# Altium schematic sheet ThinkBoard-LoRa-Node-V1.SchDoc
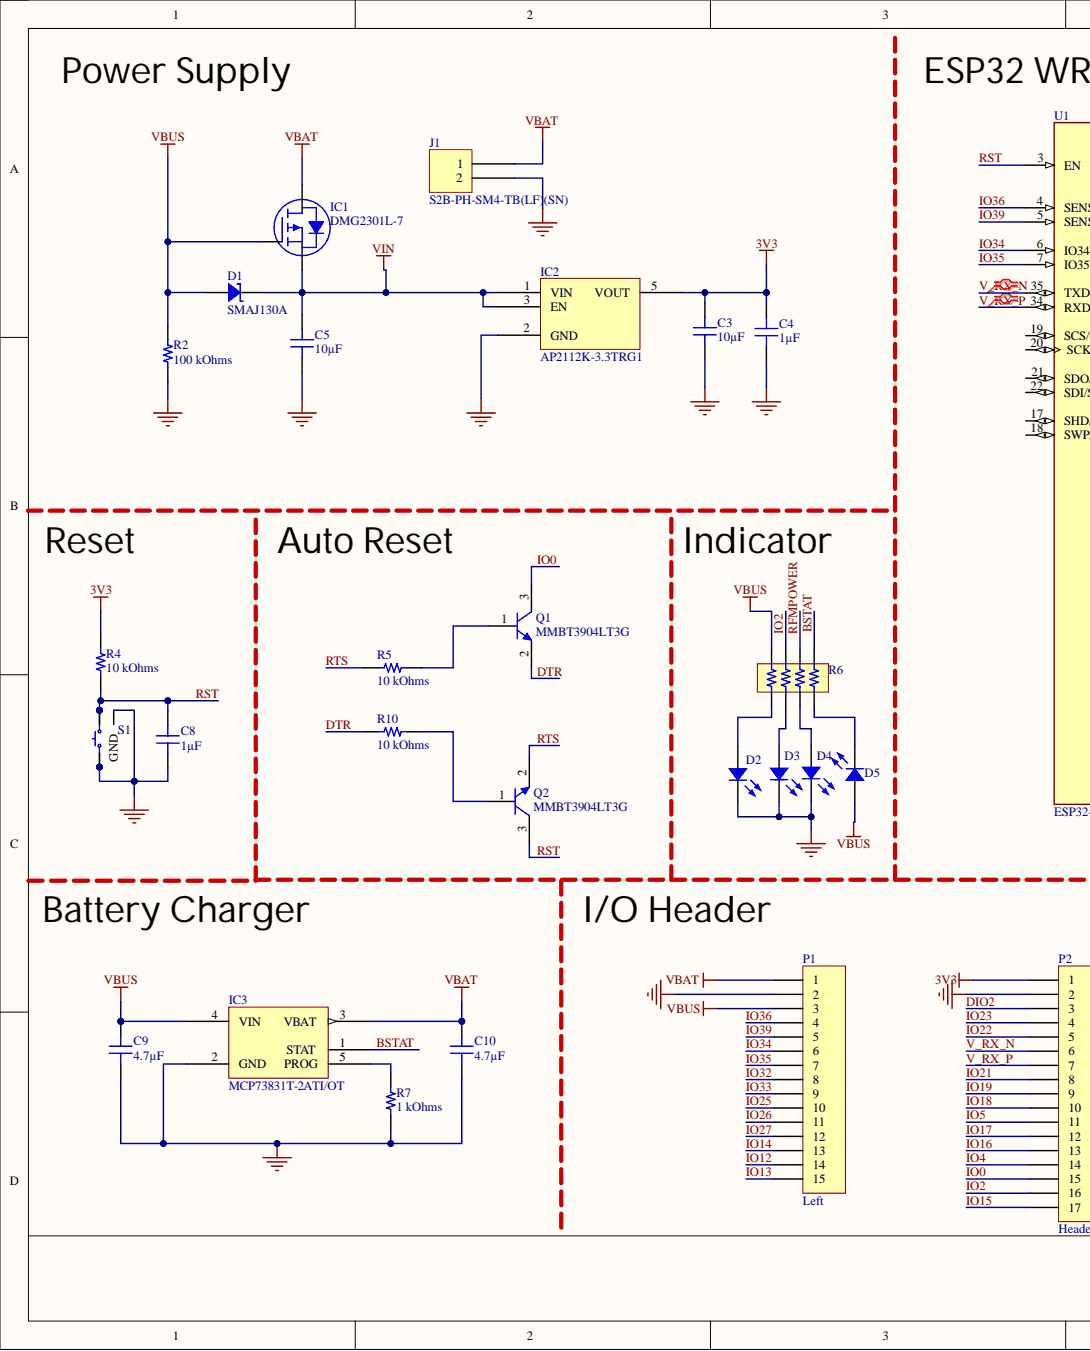
[diagram p0: ThinkBoard-LoRa-Node-V1.SchDoc, left]
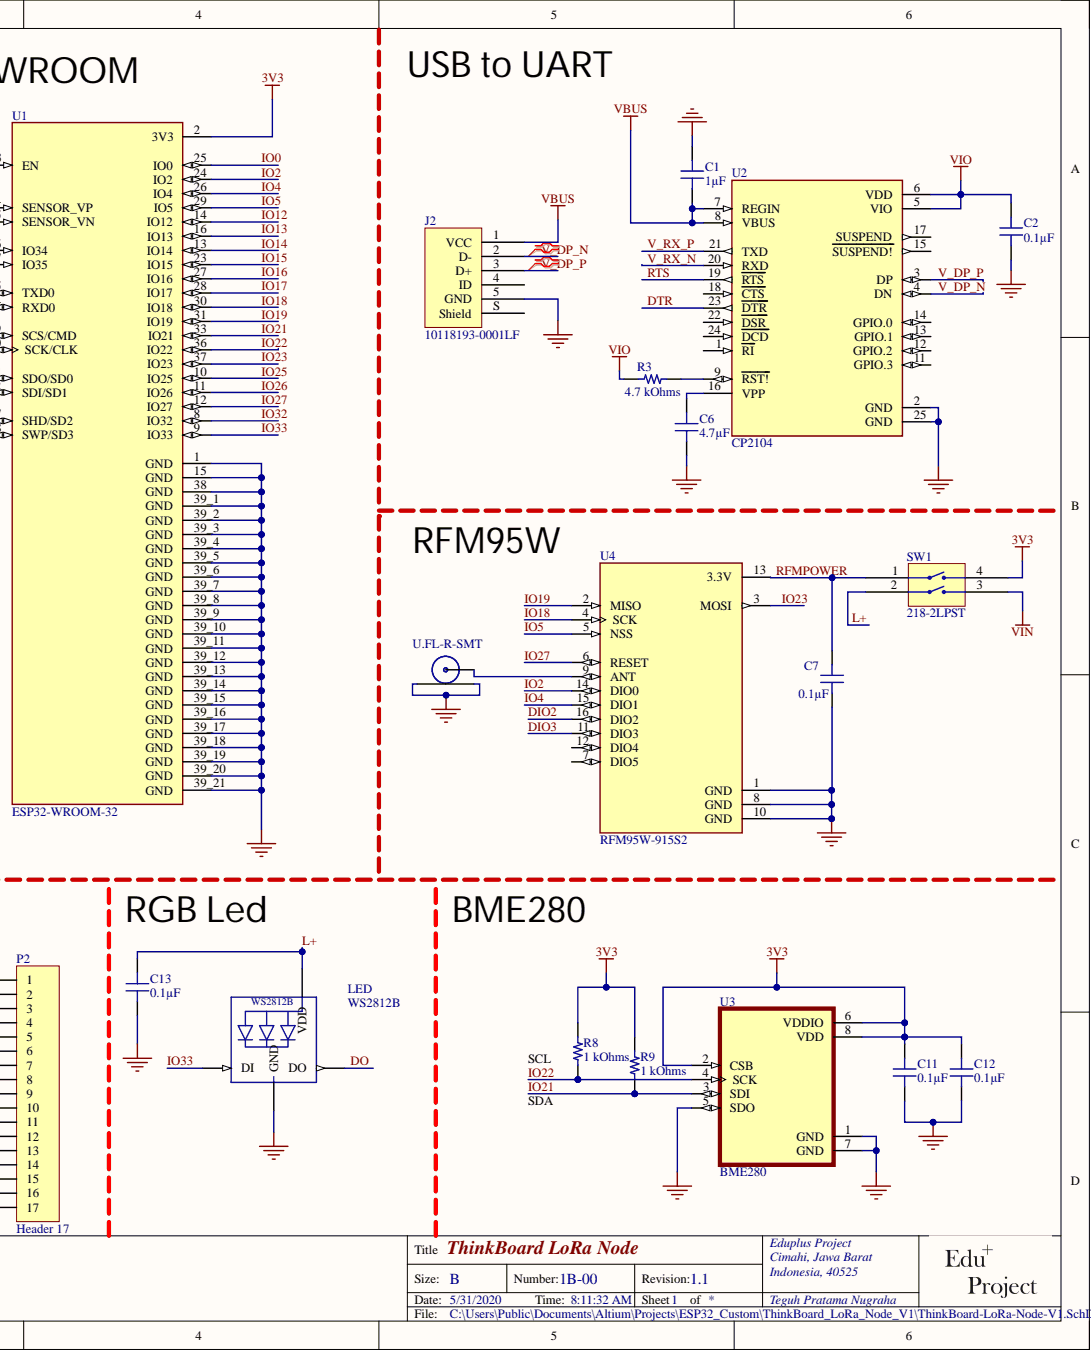
[diagram p1: ThinkBoard-LoRa-Node-V1.SchDoc, right]

## Parts
C1  1µF  [CAP 0603_1608]
C2  0.1µF  [CAP 0603_1608]
C3  10µF  [CAP 0603_1608]
C4  1µF  [CAP 0603_1608]
C5  10µF  [CAP 0603_1608]
C6  4.7µF  [CAP 0603_1608]
C7  0.1µF  [CAP 0603_1608]
C8  1µF  [CAP 0603_1608]
C9  4.7µF  [CAP 0805_2012]
C10  4.7µF  [CAP 0805_2012]
C11  0.1µF  [CAP 0603_1608]
C12  0.1µF  [CAP 0603_1608]
C13  0.1µF  [CAP 0603_1608]
D1  SMAJ130A  [DO214AC SMA]
D2  Yellow  [LED 0603_1608 YELLOW]  (value not drawn)
D3  Blue  [LED 0603_1608 BLUE]  (value not drawn)
D4  Green  [LED 0603_1608 GREEN]  (value not drawn)
D5  Red  [LED 0603_1608 RED]  (value not drawn)
IC1  DMG2301L-7  [DIODES SOT-23-3]
IC2  AP2112K-3.3TRG1  [DIODES SOT-23-5 W5]
IC3  MCP73831T-2ATI/OT  [MICROCHIP SOT-23-5 OT]
J1  S2B-PH-SM4-TB(LF)(SN)  [JST S2B-PH-SM4-TB]
J2  10118193-0001LF  [FCI USB 10118193-0001LF]
LED  WS2812B  [LED3535]
P1  Left  [HDR1X15]
P2  Header 17  [HDR1X17]
Q1  MMBT3904LT3G  [ON SEMI SOT-23-3]
Q2  MMBT3904LT3G  [ON SEMI SOT-23-3]
R2  100 kOhms  [RES 0603_1608]
R3  4.7 kOhms  [RES 0603_1608]
R4  10 kOhms  [RES 0603_1608]
R5  10 kOhms  [RES 0603_1608]
R6  EXB-28V112JX  [RESNET 0804-8 CONVEX]  (value not drawn)
R7  1 kOhms  [RES 0603_1608]
R8  1 kOhms  [RES 0603_1608]
R9  1 kOhms  [RES 0603_1608]
R10  10 kOhms  [RES 0603_1608]
S1  FSM1LP  [TE FSM1LPATR]  (value not drawn)
SW1  218-2LPST  [CTS 218-2LPJ]
U1  ESP32-WROOM-32  [MODULE_ESP32-WROOM-32]
U2  CP2104  [QFN50P400X400X80-25N]
U3  BME280  [PSON65P250X250X100-8N]
U4  RFM95W-915S2  [XCVR_RFM95W-915S2]
  U.FL-R-SMT  [U.FL-R-SMT]

## Nets
3V3: C3.2 C4.2 C11.2 C12.2 IC2.5(VOUT) P2.1 R4.2 R8.2 R9.2 SW1.4 U1.2(3V3) U3.2(CSB) U3.6(VDDIO) U3.8(VDD)
GND: C1.2 C2.1 C3.1 C4.1 C5.1 C6.1 C7.1 C8.1 C9.1 C10.1 C11.1 C12.1 C13.2 D2.2 D3.2 D4.2 IC2.2(GND) IC3.2(GND) J1.2 J2.5(GND) LED.3-GND(GND) P1.2 P2.2 R2.1 R7.1 S1.1 S1.2 S1.5(GND) U1.1(GND) U1.15(GND) U1.38(GND) U1.39_1(GND) U1.39_2(GND) U1.39_3(GND) U1.39_4(GND) U1.39_5(GND) U1.39_6(GND) U1.39_7(GND) U1.39_8(GND) U1.39_9(GND) U1.39_10(GND) U1.39_11(GND) U1.39_12(GND) U1.39_13(GND) U1.39_14(GND) U1.39_15(GND) U1.39_16(GND) U1.39_17(GND) U1.39_18(GND) U1.39_19(GND) U1.39_20(GND) U1.39_21(GND) U2.2(GND) U2.25(GND) U3.1(GND) U3.5(SDO) U3.7(GND) U4.1(GND) U4.8(GND) U4.10(GND) .P$2 .P$3
Net_P$1: U4.9(ANT) .P$1
VBAT: C10.2 IC1.3 IC3.3(VBAT) J1.1 P1.1
VBUS: C1.1 C9.2 D1.1 D5.1 IC1.1 IC3.4(VIN) J2.1(VCC) P1.3 R2.2 R6.4 U2.7(REGIN) U2.8(VBUS)
VIN: C5.2 D1.2 IC1.2 IC2.1(VIN) IC2.3(EN) SW1.3
VIO: C2.2 R3.1 U2.5(VIO) U2.6(VDD)
BSTAT: IC3.1(STAT) R6.1
DIO2: P2.3 U4.16(DIO2)
DIO3: U4.11(DIO3)
DO: LED.2-DOUT(DO)
DTR: Q1.2 R10.2 U2.23(DTR)
IO0: P2.15 Q1.3 U1.25(IO0)
IO2: P2.16 R6.3 U1.24(IO2) U4.14(DIO0)
IO4: P2.14 U1.26(IO4) U4.15(DIO1)
IO5: P2.11 U1.29(IO5) U4.5(NSS)
IO12: P1.14 U1.14(IO12)
IO13: P1.15 U1.16(IO13)
IO14: P1.13 U1.13(IO14)
IO15: P2.17 U1.23(IO15)
IO16: P2.13 U1.27(IO16)
IO17: P2.12 U1.28(IO17)
IO18: P2.10 U1.30(IO18) U4.4(SCK)
IO19: P2.9 U1.31(IO19) U4.2(MISO)
IO21: P2.8 R9.1 U1.33(IO21) U3.3(SDI)
IO22: P2.5 R8.1 U1.36(IO22) U3.4(SCK)
IO23: P2.4 U1.37(IO23) U4.3(MOSI)
IO25: P1.10 U1.10(IO25)
IO26: P1.11 U1.11(IO26)
IO27: P1.12 U1.12(IO27) U4.6(RESET)
IO32: P1.8 U1.8(IO32)
IO33: LED.4-DIN(DI) P1.9 U1.9(IO33)
IO34: P1.6 U1.6(IO34)
IO35: P1.7 U1.7(IO35)
IO36: P1.4 U1.4(SENSOR_VP)
IO39: P1.5 U1.5(SENSOR_VN)
L+: C13.1 LED.1-VDD(VDD) SW1.2
RFMPOWER: C7.2 R6.2 SW1.1 U4.13(3.3V)
RST: C8.2 Q2.3 R4.1 S1.3 S1.4 U1.3(EN)
RTS: Q2.2 R5.2 U2.19(RTS)
V_DP_N: J2.2(D-) U2.4(DN)
V_DP_P: J2.3(D+) U2.3(DP)
V_RX_N: P2.6 U1.35(TXD0) U2.20(RXD)
V_RX_P: P2.7 U1.34(RXD0) U2.21(TXD)
NetC6_2: C6.2 U2.16(VPP)
NetD2_1: D2.1 R6.8
NetD3_1: D3.1 R6.7
NetD4_1: D4.1 R6.6
NetD5_2: D5.2 R6.5
NetIC3_5: IC3.5(PROG) R7.2
NetQ1_1: Q1.1 R5.1
NetQ2_1: Q2.1 R10.1
NetR3_2: R3.2 U2.9(RST!)

Not connected: J2 4(ID) S(Shield); U1 17(SHD/SD2) 18(SWP/SD3) 19(SCS/CMD) 20(SCK/CLK) 21(SDO/SD0) 22(SDI/SD1); U2 1(RI) 11(GPIO.3) 12(GPIO.2) 13(GPIO.1) 14(GPIO.0) 15(SUSPEND!) 17(SUSPEND) 18(CTS) 22(DSR) 24(DCD); U4 7(DIO5) 12(DIO4)

## Library data of the parts
C1 C4 C8 = C0603C105K4RACAUTO: CAP CER 0603 1UF 16V X7R 10%; Manufacturer: KEMET; Database Table Name: Capacitors - Ceramic - 0603; Applications: Automotive, Bypass, Decoupling; Capacitance: 1µF; Component Kind: Standard; ComponentLink1Description: Datasheet; ComponentLink1URL: https://api.kemet.com/component-edge/download/datasheet/C0603C105K4RACAUTO.pdf; ComponentLink2Description: DigiKey Link; ComponentLink2URL: http://digikey.com/product-detail/en/kemet/C0603C105K4RACAUTO/399-17704-1-ND/8635855; Device Package: 0603 (1608 Metric); LastUpdated: 1/21/2020 12:02:09 AM; Library Name: Celestial Altium Library - altium_library (3).DbLib; Lifecycle Status: Active; Minimum Order: 1; Mounting Type: Surface Mount, MLCC; Operating Temperature: -55°C ~ 125°C; Package / Case: 0603 (1608 Metric); Packaging: TapeAndReel; Part Number: C0603C105K4RACAUTO; Part Status: Active; PartId: 2179; Price: 0.02; Ratings: AEC-Q200; Series: SMD Auto X7R; Size / Dimension: 0.063" L x 0.031" W (1.60mm x 0.80mm); Supplier 1: DigiKey; Supplier Part Number 1: 399-17704-1-ND; Temperature Coefficient: X7R; Thickness (Max): 0.037" (0.95mm); Tolerance: ±10%; Value: 1µF; Voltage - Rated: 16V
C2 C7 C11-C13 = C0603C105K4RACAUTO: CAP CER 0603 1UF 16V X7R 10%; Manufacturer: KEMET; Capacitance: 1µF; Database Table Name: Capacitors - Ceramic - 0603; Applications: Automotive, Bypass, Decoupling; Component Kind: Standard; ComponentLink1Description: Datasheet; ComponentLink1URL: https://api.kemet.com/component-edge/download/datasheet/C0603C105K4RACAUTO.pdf; ComponentLink2Description: DigiKey Link; ComponentLink2URL: http://digikey.com/product-detail/en/kemet/C0603C105K4RACAUTO/399-17704-1-ND/8635855; Device Package: 0603 (1608 Metric); LastUpdated: 1/21/2020 12:02:09 AM; Library Name: Celestial Altium Library - altium_library (3).DbLib; Lifecycle Status: Active; Minimum Order: 1; Mounting Type: Surface Mount, MLCC; Operating Temperature: -55°C ~ 125°C; Package / Case: 0603 (1608 Metric); Packaging: TapeAndReel; Part Number: C0603C105K4RACAUTO; Part Status: Active; PartId: 2179; Price: 0.02; Ratings: AEC-Q200; Series: SMD Auto X7R; Size / Dimension: 0.063" L x 0.031" W (1.60mm x 0.80mm); Supplier 1: DigiKey; Supplier Part Number 1: 399-17704-1-ND; Temperature Coefficient: X7R; Thickness (Max): 0.037" (0.95mm); Tolerance: ±10%; Value: 0.1µF; Voltage - Rated: 16V
C3 C5 = GRM188R60J106ME47D: CAP CER 10UF 6.3V X5R 0603; Manufacturer: Murata Electronics; Database Table Name: Capacitors - Ceramic - 0603; Tolerance: ±20%; Applications: General Purpose; Capacitance: 10µF; Component Kind: Standard; ComponentLink1Description: Datasheet; ComponentLink1URL: https://www.murata.com/~/media/webrenewal/support/library/catalog/products/capacitor/mlcc/c02e.ashx?la=en-us; ComponentLink2Description: DigiKey Link; ComponentLink2URL: http://digikey.com/product-detail/en/murata-electronics/GRM188R60J106ME47D/490-3896-1-ND/965938; Device Package: 0603 (1608 Metric); LastUpdated: 1/21/2020 12:02:09 AM; Library Name: Celestial Altium Library - altium_library (3).DbLib; Lifecycle Status: Active; Minimum Order: 1; Mounting Type: Surface Mount, MLCC; Operating Temperature: -55°C ~ 85°C; Package / Case: 0603 (1608 Metric); Packaging: TapeAndReel; Part Number: GRM188R60J106ME47D; Part Status: Active; PartId: 2351; Price: 0.08; Series: GRM; Size / Dimension: 0.063" L x 0.031" W (1.60mm x 0.80mm); Supplier 1: DigiKey; Supplier Part Number 1: 490-3896-1-ND; Temperature Coefficient: X5R; Thickness (Max): 0.035" (0.90mm); Value: 10µF; Voltage - Rated: 6.3V
C6 = CL10A475KA8NQNC: CAP CER 4.7UF 25V X5R 0603; Manufacturer: Samsung Electro-Mechanics; Database Table Name: Capacitors - Ceramic - 0603; Tolerance: ±10%; Applications: General Purpose; Capacitance: 4.7µF; Component Kind: Standard; ComponentLink1Description: Datasheet; ComponentLink1URL: http://www.samsungsem.com/kr/support/product-search/mlcc/CL10A475KA8NQNC.jsp; ComponentLink2Description: DigiKey Link; ComponentLink2URL: http://digikey.com/product-detail/en/samsung-electro-mechanics/CL10A475KA8NQNC/1276-1900-1-ND/3889986; Device Package: 0603 (1608 Metric); LastUpdated: 1/21/2020 12:02:09 AM; Library Name: Celestial Altium Library - altium_library (3).DbLib; Lifecycle Status: Active; Minimum Order: 1; Mounting Type: Surface Mount, MLCC; Operating Temperature: -55°C ~ 85°C; Package / Case: 0603 (1608 Metric); Packaging: TapeAndReel; Part Number: CL10A475KA8NQNC; Part Status: Active; PartId: 2349; Price: 0.07; Series: CL; Size / Dimension: 0.063" L x 0.031" W (1.60mm x 0.80mm); Supplier 1: DigiKey; Supplier Part Number 1: 1276-1900-1-ND; Temperature Coefficient: X5R; Thickness (Max): 0.035" (0.90mm); Value: 4.7µF; Voltage - Rated: 25V
C9 C10 = C0805X475K4RAC7800: CAP CER 4.7UF 16V X7R 0805; Manufacturer: KEMET; Database Table Name: Capacitors - Ceramic - 0805; Tolerance: ±10%; Applications: Boardflex Sensitive; Capacitance: 4.7µF; Component Kind: Standard; ComponentLink1Description: Datasheet; ComponentLink1URL: https://api.kemet.com/component-edge/download/datasheet/C0805X475K4RAC7800.pdf; ComponentLink2Description: DigiKey Link; ComponentLink2URL: http://digikey.com/product-detail/en/kemet/C0805X475K4RAC7800/399-15712-1-ND/7427592; Device Package: 0805 (2012 Metric); Features: Soft Termination; LastUpdated: 1/21/2020 12:03:03 AM; Library Name: Celestial Altium Library - altium_library (3).DbLib; Lifecycle Status: Active; Minimum Order: 1; Mounting Type: Surface Mount, MLCC; Operating Temperature: -55°C ~ 125°C; Package / Case: 0805 (2012 Metric); Packaging: TapeAndReel; Part Number: C0805X475K4RAC7800; Part Status: Active; PartId: 4698; Price: 0.21; Series: SMD Comm X7R Flex; Size / Dimension: 0.079" L x 0.049" W (2.00mm x 1.25mm); Supplier 1: DigiKey; Supplier Part Number 1: 399-15712-1-ND; Temperature Coefficient: X7R; Thickness (Max): 0.057" (1.45mm); Value: 4.7µF; Voltage - Rated: 16V
D1 = SMAJ130A: TVS DIODE 130V 209V SMA; Manufacturer: Bourns Inc.; Current - Peak Pulse (10/1000µs): 1.9A; Database Table Name: Diodes - TVS; Power - Peak Pulse: 400W; Power Line Protection: No; Type: Zener; Unidirectional Channels: 1; Voltage - Breakdown (Min): 144V; Applications: General Purpose; Component Kind: Standard; ComponentLink1Description: Datasheet; ComponentLink1URL: https://www.bourns.com/docs/Product-Datasheets/SMAJ.pdf; ComponentLink2Description: DigiKey Link; ComponentLink2URL: http://digikey.com/product-detail/en/bourns-inc/SMAJ130A/SMAJ130ABCT-ND/2253538; Device Package: DO-214AC, SMA; LastUpdated: 3/21/2020 12:56:27 AM; Library Name: Celestial Altium Library - altium_library (3).DbLib; Lifecycle Status: Active; Minimum Order: 1; Mounting Type: Surface Mount; Operating Temperature: -55°C ~ 150°C (TJ); Package / Case: DO-214AC, SMA; Packaging: TapeAndReel; Part Number: SMAJ130A; Part Status: Active; PartId: 5853; Price: 0.09; Series: SMAJ; Supplier 1: DigiKey; Supplier Device Package: SMA (DO-214AC); Supplier Part Number 1: SMAJ130ABCT-ND; Voltage - Clamping (Max) @ Ipp: 209V; Voltage - Reverse Standoff (Typ): 130V
D2 = BL-HKC36D-AV-TRB: 0603 YELLOW; Manufacturer: American Bright Optoelectronics Corporation; Configuration: 0603; Current - Test: 20mA; Database Table Name: LED - Indication; Lens Color: Colorless; Lens Size: 0.87mm x 0.80mm; Lens Style: Rectangle with Flat Top; Lens Transparency: Clear; Millicandela Rating: 120mcd; Viewing Angle: 120°; Voltage - Forward (Vf) (Typ): 2V; Wavelength - Dominant: 589nm; Wavelength - Peak: 590nm; Color: Yellow; Component Kind: Standard; ComponentLink1Description: Datasheet; ComponentLink1URL: http://americanbrightled.com/pdffiles/smt/BL-HKC36D-AV-TRB.pdf; ComponentLink2Description: DigiKey Link; ComponentLink2URL: http://digikey.com/product-detail/en/american-bright-optoelectronics-corporation/BL-HKC36D-AV-TRB/BL-HKC36D-AV-TRBCT-ND/9678339; Device Package: 0603 (1608 Metric); Height (Max): 0.85mm; LastUpdated: 1/21/2020 3:15:05 AM; Library Name: Celestial Altium Library - altium_library (3).DbLib; Lifecycle Status: Active; Minimum Order: 1; Mounting Type: Surface Mount; Package / Case: 0603 (1608 Metric); Packaging: TapeAndReel; Part Number: BL-HKC36D-AV-TRB; Part Status: Active; PartId: 82; Price: 0.06; Series: -; Size / Dimension: 1.60mm L x 0.80mm W; Supplier 1: DigiKey; Supplier Device Package: 0603; Supplier Part Number 1: BL-HKC36D-AV-TRBCT-ND; Value: Yellow
D3 = HSMR-C191: LED BLUE DIFFUSED CHIP SMD; Manufacturer: Broadcom Limited; Configuration: Standard; Current - Test: 20mA; Database Table Name: LED - Indication; Lens Color: White; Lens Size: 1.00mm x 0.80mm; Lens Style: Rectangle with Flat Top; Millicandela Rating: 55mcd; Viewing Angle: 155°; Voltage - Forward (Vf) (Typ): 3.4V; Wavelength - Dominant: 473nm; Wavelength - Peak: 469nm; Color: Blue; Component Kind: Standard; ComponentLink1Description: Datasheet; ComponentLink1URL: https://docs.broadcom.com/docs/AV02-0977EN; ComponentLink2Description: DigiKey Link; ComponentLink2URL: http://digikey.com/product-detail/en/broadcom-limited/HSMR-C191/516-2523-1-ND/3199590; Device Package: 0603 (1608 Metric); Height (Max): 0.60mm; LastUpdated: 1/21/2020 3:10:56 AM; Lens Transparency: Diffused; Library Name: Celestial Altium Library - altium_library (3).DbLib; Lifecycle Status: Active; Minimum Order: 1; Mounting Type: Surface Mount; Package / Case: 0603 (1608 Metric); Packaging: TapeAndReel; Part Number: HSMR-C191; Part Status: Active; PartId: 791; Price: 0.25; Series: -; Size / Dimension: 1.60mm L x 0.80mm W; Supplier 1: DigiKey; Supplier Device Package: Chip LED; Supplier Part Number 1: 516-2523-1-ND; Value: Blue
D4 = QTLP601C4TR: LED GREEN CLEAR 0603 SMD; Manufacturer: Everlight Electronics Co Ltd; Configuration: Standard; Current - Test: 20mA; Database Table Name: LED - Indication; Lens Color: Colorless; Lens Size: 1.20mm x 0.80mm; Lens Style: Rectangle with Flat Top; Millicandela Rating: 15mcd; Viewing Angle: 120°; Voltage - Forward (Vf) (Typ): 2.1V; Wavelength - Dominant: 570nm; Wavelength - Peak: 565nm; Color: Green; Component Kind: Standard; ComponentLink1Description: Datasheet; ComponentLink1URL: //media.digikey.com/pdf/Data%20Sheets/Everlight%20PDFs/QTLP601C-2,3,4,7,B.pdf; ComponentLink2Description: DigiKey Link; ComponentLink2URL: http://digikey.com/product-detail/en/everlight-electronics-co-ltd/QTLP601C4TR/1080-1405-1-ND/2676139; Device Package: 0603 (1608 Metric); Height (Max): 0.60mm; LastUpdated: 1/21/2020 3:12:15 AM; Lens Transparency: Clear; Library Name: Celestial Altium Library - altium_library (3).DbLib; Lifecycle Status: Active; Minimum Order: 1; Mounting Type: Surface Mount; Package / Case: 0603 (1608 Metric); Packaging: TapeAndReel; Part Number: QTLP601C4TR; Part Status: Active; PartId: 584; Price: 0.07; Series: -; Size / Dimension: 1.60mm L x 0.80mm W; Supplier 1: DigiKey; Supplier Device Package: 0603; Supplier Part Number 1: 1080-1405-1-ND; Value: Green
D5 = 5988010102F: LED RED CLEAR 0603 SMD; Manufacturer: Dialight; Configuration: Standard; Current - Test: 20mA; Database Table Name: LED - Indication; Lens Color: Colorless; Lens Size: 1.10mm x 0.80mm; Lens Style: Rectangle with Flat Top; Millicandela Rating: 60mcd; Viewing Angle: 140°; Voltage - Forward (Vf) (Typ): 2V; Wavelength - Dominant: 635nm; Color: Red; Component Kind: Standard; ComponentLink1Description: Datasheet; ComponentLink1URL: //media.digikey.com/pdf/Data%20Sheets/Dialight%20PDFs/598_Series_0603_Pkg.pdf; ComponentLink2Description: DigiKey Link; ComponentLink2URL: http://digikey.com/product-detail/en/dialight/5988010102F/350-2899-ND/2432626; Device Package: 0603 (1608 Metric); Height (Max): 0.70mm; LastUpdated: 1/21/2020 3:13:21 AM; Lens Transparency: Clear; Library Name: Celestial Altium Library - altium_library (3).DbLib; Lifecycle Status: Active; Minimum Order: 1; Mounting Type: Surface Mount; Package / Case: 0603 (1608 Metric); Packaging: Strip; Part Number: 5988010102F; Part Status: Active; PartId: 448; Price: 0.51; Series: 598; Size / Dimension: 1.60mm L x 0.80mm W; Supplier 1: DigiKey; Supplier Device Package: 0603; Supplier Part Number 1: 350-2899-ND; Value: Red
IC1 = DMG2301L-7: MOSFET P-CH 20V 3A SOT23; Manufacturer: Diodes Incorporated; Current - Continuous Drain (Id) @ 25°C: 3A (Ta); Database Table Name: MOSFET - Single - P-Ch; Drive Voltage (Max Rds On,  Min Rds On): 2.5V, 4.5V; FET Type: P-Channel; Gate Charge (Qg) (Max) @ Vgs: 5.5nC @ 4.5V; Input Capacitance (Ciss) (Max) @ Vds: 476pF @ 10V; Power Dissipation (Max): 1.5W (Ta); Rds On (Max) @ Id, Vgs: 120mOhm @ 2.8A, 4.5V; Vgs (Max): ±8V; Vgs(th) (Max) @ Id: 1.2V @ 250µA; Component Kind: Standard; ComponentLink1Description: Datasheet; ComponentLink1URL: https://www.diodes.com/assets/Datasheets/DMG2301L.pdf; ComponentLink2Description: DigiKey Link; ComponentLink2URL: http://digikey.com/product-detail/en/diodes-incorporated/DMG2301L-7/DMG2301L-7DICT-ND/8545979; Device Package: TO-236-3, SC-59, SOT-23-3; Drain to Source Voltage (Vdss): 20V; LastUpdated: 1/21/2020 4:00:37 AM; Library Name: Celestial Altium Library - altium_library (3).DbLib; Lifecycle Status: Active; Minimum Order: 1; Mounting Type: Surface Mount; Operating Temperature: -55°C ~ 150°C (TJ); Package / Case: TO-236-3, SC-59, SOT-23-3; Packaging: TapeAndReel; Part Number: DMG2301L-7; Part Status: Active; Price: 0.06; Series: -; Supplier 1: DigiKey; Supplier Device Package: SOT-23; Supplier Part Number 1: DMG2301L-7DICT-ND; Technology: MOSFET (Metal Oxide)
IC2 = AP2112K-3.3TRG1: IC REG LINEAR 3.3V 600MA SOT25; Manufacturer: Diodes Incorporated; Control Features: Enable; Current - Quiescent (Iq): 80µA; Database Table Name: Voltage Regulators - Linear; Number of Regulators: 1; Output Configuration: Positive; Output Type: Fixed; Protection Features: Over Current, Over Temperature; PSRR: 65sB (100Hz ~ 1KHz); Voltage - Input (Max): 6V; Voltage Dropout (Max): 0.4V @ 600mA; Component Kind: Standard; ComponentLink1Description: Datasheet; ComponentLink1URL: https://www.diodes.com/assets/Datasheets/AP2112.pdf; ComponentLink2Description: DigiKey Link; ComponentLink2URL: http://digikey.com/product-detail/en/diodes-incorporated/AP2112K-3.3TRG1/AP2112K-3.3TRG1DICT-ND/4505257; Current - Output: 600mA; Device Package: SC-74A, SOT-753; LastUpdated: 1/21/2020 4:09:18 AM; Library Name: Celestial Altium Library - altium_library (3).DbLib; Lifecycle Status: Active; Minimum Order: 1; Mounting Type: Surface Mount; Operating Temperature: -40°C ~ 85°C; Package / Case: SC-74A, SOT-753; Packaging: TapeAndReel; Part Number: AP2112K-3.3TRG1; Part Status: Active; Price: 0.10; Series: -; Supplier 1: DigiKey; Supplier Device Package: SOT-25; Supplier Part Number 1: AP2112K-3.3TRG1DICT-ND; Voltage - Output (Min/Fixed): 3.3V
IC3 = MCP73831T-2ATI/OT: IC CONTROLLR LI-ION 4.2V SOT23-5; Manufacturer: Microchip Technology; Battery Chemistry: Lithium Ion/Polymer; Charge Current - Max: 500mA; Current - Charging: Constant - Programmable; Database Table Name: Battery Chargers; Fault Protection: Over Voltage; Number of Cells: 1; Programmable Features: Current; Voltage - Supply (Max): 6V; Battery Pack Voltage: 4.2V; Component Kind: Standard; ComponentLink1Description: Datasheet; ComponentLink1URL: http://ww1.microchip.com/downloads/en/DeviceDoc/20001984g.pdf; ComponentLink2Description: DigiKey Link; ComponentLink2URL: //digikey.com/product-detail/en/microchip-technology/MCP73831T-2ATI-OT/MCP73831T-2ATI-OTTR-ND/964303; Device Package: SC-74A, SOT-753; LastUpdated: 12/3/2019 11:33:03 PM; Library Name: Celestial Altium Library - altium_library (3).DbLib; Lifecycle Status: 0; Minimum Order: 1; Mounting Type: Surface Mount; Operating Temperature: -40°C ~ 85°C (TA); Package / Case: SC-74A, SOT-753; Packaging: TapeAndReel; Part Number: MCP73831T-2ATI/OT; Part Status: Active; PartId: 1; Price: 0.42; Series: -; Supplier 1: DigiKey; Supplier Device Package: SOT-23-5; Supplier Part Number 1: MCP73831T-2ATI/OTTR-ND
J1 = S2B-PH-SM4-TB(LF)(SN): CONN HEADER SMD R/A 2POS 2MM; Manufacturer: JST Sales America Inc.; Contact Length - Mating: 0.130" (3.30mm); Current Rating (Amps): 2A; Database Table Name: Connectors - Rectangular Headers Male Pins; Material Flammability Rating: UL94 V-0; Number of Positions: 2; Number of Positions Loaded: All; Pitch - Mating: 0.079" (2.00mm); Shrouding: Shrouded - 4 Wall; Style: Board to Cable/Wire; Voltage Rating: 100V; Component Kind: Standard; ComponentLink1Description: Datasheet; ComponentLink1URL: http://www.jst-mfg.com/product/pdf/eng/ePH.pdf; ComponentLink2Description: DigiKey Link; ComponentLink2URL: http://digikey.com/product-detail/en/jst-sales-america-inc/S2B-PH-SM4-TB-LF-SN/455-1749-1-ND/926846; Connector Type: Header; Contact Finish - Mating: Tin; Contact Finish - Post: Tin; Contact Material: Copper Alloy; Contact Shape: Square; Contact Type: Male Pin; Fastening Type: Detent Lock; Features: Solder Retention; Insulation Color: Natural; Insulation Height: 0.217" (5.51mm); Insulation Material: Polyamide (PA6T), Nylon 6T; LastUpdated: 1/15/2020 3:45:11 AM; Library Name: Celestial Altium Library - altium_library (3).DbLib; Lifecycle Status: Active; Minimum Order: 1; Mounting Type: Surface Mount, Right Angle; Number of Rows: 1; Operating Temperature: -25°C ~ 85°C; Packaging: TapeAndReel; Part Number: S2B-PH-SM4-TB(LF)(SN); Part Status: Active; PartId: 115; Price: 0.27; Series: PH; Supplier 1: DigiKey; Supplier Part Number 1: 455-1749-1-ND; Termination: Solder
J2 = 10118193-0001LF: CONN RCPT USB2.0 MICRO B SMD R/A; Manufacturer: Amphenol ICC (FCI); Connector Type: USB - micro B; Current Rating (Amps): 1.8A per Contact; Database Table Name: Connectors - USB DVI HDMI; Gender: Receptacle; Mating Cycles: 10000; Number of Contacts: 5; Number of Ports: 1; Shielding: Shielded; Voltage - Rated: 100VAC; Component Kind: Standard; ComponentLink1Description: Datasheet; ComponentLink1URL: https://cdn.amphenol-icc.com/media/wysiwyg/files/documentation/datasheet/inputoutput/io_usb_micro.pdf; ComponentLink2Description: DigiKey Link; ComponentLink2URL: //digikey.com/product-detail/en/amphenol-icc-fci/10118193-0001LF/609-4616-2-ND/2785388; Features: Solder Retention; LastUpdated: 12/9/2019 8:51:28 PM; Library Name: Celestial Altium Library - altium_library (3).DbLib; Lifecycle Status: 0; Minimum Order: 1; Mounting Feature: Horizontal; Mounting Type: Surface Mount, Right Angle; Through Hole; Operating Temperature: -30°C ~ 80°C; Packaging: TapeAndReel; Part Number: 10118193-0001LF; Part Status: Active; Price: 0.21; Series: -; Specifications: USB 2.0; Supplier 1: DigiKey; Supplier Part Number 1: 609-4616-2-ND; Termination: Solder
LED = WS2812B: Raspberry Pi HAT _40 pin_ Wheeled Robotics Kit; MF: SparkFun Electronics; Availability: Unavailable; Component Kind: Standard; Library Name: WS2812B.IntLib; MP: WS2812B; Package: None; Price: None; SnapEDA_Link: https://www.snapeda.com/parts/WS2812B/Worldsemi/view-part/1038297/?ref=snap
P1 = Header 15: Header, 15-Pin; Component Kind: Standard; LatestRevisionDate: 17-Jul-2002; LatestRevisionNote: Re-released for DXP Platform.; Library Name: Miscellaneous Connectors.IntLib; Signal Integrity: Connector; Publisher: Altium Limited
P2 = Header 17: Header, 17-Pin; Component Kind: Standard; LatestRevisionDate: 17-Jul-2002; LatestRevisionNote: Re-released for DXP Platform.; Library Name: Miscellaneous Connectors.IntLib; Signal Integrity: Connector; Publisher: Altium Limited
Q1 Q2 = MMBT3904LT3G: TRANS NPN 40V 0.2A SOT23; Manufacturer: ON Semiconductor; Current - Collector (Ic) (Max): 200mA; Database Table Name: Transistors - Bipolar BJT - Single; DC Current Gain (hFE) (Min) @ Ic, Vce: 100 @ 10mA, 1V; Frequency - Transition: 300MHz; Power - Max: 300mW; Vce Saturation (Max) @ Ib, Ic: 300mV @ 5mA, 50mA; Component Kind: Standard; ComponentLink1Description: Datasheet; ComponentLink1URL: http://www.onsemi.com/pub/Collateral/MMBT3904LT1-D.PDF; ComponentLink2Description: DigiKey Link; ComponentLink2URL: http://digikey.com/product-detail/en/on-semiconductor/MMBT3904LT3G/MMBT3904LT3GOSCT-ND/1139814; Device Package: TO-236-3, SC-59, SOT-23-3; LastUpdated: 3/30/2020 2:53:25 PM; Library Name: Celestial Altium Library - altium_library (3).DbLib; Lifecycle Status: Active; Minimum Order: 1; Mounting Type: Surface Mount; Operating Temperature: -55°C ~ 150°C (TJ); Package / Case: TO-236-3, SC-59, SOT-23-3; Packaging: TapeAndReel; Part Number: MMBT3904LT3G; Part Status: Active; PartId: 159; Price: 0.02; Series: -; Supplier 1: DigiKey; Supplier Device Package: SOT-23-3 (TO-236); Supplier Part Number 1: MMBT3904LT3GOSCT-ND; Transistor Type: NPN; Voltage - Collector Emitter Breakdown (Max): 40V
R2 = RNCS0603BKE100K: RES 100K OHM 0.1% 1/16W 0603; Manufacturer: Stackpole Electronics Inc; Database Table Name: Resistors - Surface Mount - 0603; Power (Watts): 0.063W, 1/16W; Temperature Coefficient: ±25ppm/°C; Component Kind: Standard; ComponentLink1Description: Datasheet; ComponentLink1URL: https://www.seielect.com/catalog/sei-rncs_rnch.pdf; ComponentLink2Description: DigiKey Link; ComponentLink2URL: http://digikey.com/product-detail/en/stackpole-electronics-inc/RNCS0603BKE100K/RNCS0603BKE100KCT-ND/1800112; Composition: Thin Film; Device Package: 0603 (1608 Metric); Features: Anti-Corrosive, Automotive AEC-Q200, Moisture Resistant; Height - Seated (Max): 0.022" (0.55mm); LastUpdated: 1/21/2020 12:25:01 AM; Library Name: Celestial Altium Library - altium_library (3).DbLib; Lifecycle Status: Active; Minimum Order: 1; Number of Terminations: 2; Operating Temperature: -55°C ~ 155°C; Package / Case: 0603 (1608 Metric); Packaging: TapeAndReel; Part Number: RNCS0603BKE100K; Part Status: Active; PartId: 4849; Price: 0.08; Ratings: AEC-Q200; Resistance: 100 kOhms; Series: RNCS; Size / Dimension: 0.061" L x 0.031" W (1.55mm x 0.80mm); Supplier 1: DigiKey; Supplier Device Package: 0603; Supplier Part Number 1: RNCS0603BKE100KCT-ND; Tolerance: ±0.1%; Value: 100 kOhms
R3 = ERJ-3EKF4701V: RES SMD 4.7K OHM 1% 1/10W 0603; Manufacturer: Panasonic Electronic Components; Database Table Name: Resistors - Surface Mount - 0603; Power (Watts): 0.1W, 1/10W; Temperature Coefficient: ±100ppm/°C; Component Kind: Standard; ComponentLink1Description: Datasheet; ComponentLink1URL: https://industrial.panasonic.com/cdbs/www-data/pdf/RDA0000/AOA0000C304.pdf; ComponentLink2Description: DigiKey Link; ComponentLink2URL: http://digikey.com/product-detail/en/panasonic-electronic-components/ERJ-3EKF4701V/P4.70KHCT-ND/1746782; Composition: Thick Film; Device Package: 0603 (1608 Metric); Features: Automotive AEC-Q200; Height - Seated (Max): 0.022" (0.55mm); LastUpdated: 1/21/2020 12:24:38 AM; Library Name: Celestial Altium Library - altium_library (3).DbLib; Lifecycle Status: Active; Minimum Order: 1; Number of Terminations: 2; Operating Temperature: -55°C ~ 155°C; Package / Case: 0603 (1608 Metric); Packaging: TapeAndReel; Part Number: ERJ-3EKF4701V; Part Status: Active; PartId: 5645; Price: 0.01; Ratings: AEC-Q200; Resistance: 4.7 kOhms; Series: ERJ; Size / Dimension: 0.063" L x 0.031" W (1.60mm x 0.80mm); Supplier 1: DigiKey; Supplier Device Package: 0603; Supplier Part Number 1: P4.70KHCT-ND; Tolerance: ±1%; Value: 4.7 kOhms
R4 R5 R10 = RNCS0603BKE10K0: RES 10K OHM 0.1% 1/16W 0603; Manufacturer: Stackpole Electronics Inc; Database Table Name: Resistors - Surface Mount - 0603; Power (Watts): 0.063W, 1/16W; Temperature Coefficient: ±25ppm/°C; Component Kind: Standard; ComponentLink1Description: Datasheet; ComponentLink1URL: https://www.seielect.com/catalog/sei-rncs_rnch.pdf; ComponentLink2Description: DigiKey Link; ComponentLink2URL: http://digikey.com/product-detail/en/stackpole-electronics-inc/RNCS0603BKE10K0/RNCS0603BKE10K0CT-ND/1800113; Composition: Thin Film; Device Package: 0603 (1608 Metric); Features: Anti-Corrosive, Automotive AEC-Q200, Moisture Resistant; Height - Seated (Max): 0.022" (0.55mm); LastUpdated: 1/21/2020 12:25:01 AM; Library Name: Celestial Altium Library - altium_library (3).DbLib; Lifecycle Status: Active; Minimum Order: 1; Number of Terminations: 2; Operating Temperature: -55°C ~ 155°C; Package / Case: 0603 (1608 Metric); Packaging: TapeAndReel; Part Number: RNCS0603BKE10K0; Part Status: Active; PartId: 4838; Price: 0.08; Ratings: AEC-Q200; Resistance: 10 kOhms; Series: RNCS; Size / Dimension: 0.061" L x 0.031" W (1.55mm x 0.80mm); Supplier 1: DigiKey; Supplier Device Package: 0603; Supplier Part Number 1: RNCS0603BKE10K0CT-ND; Tolerance: ±0.1%; Value: 10 kOhms
R6 = EXB-28V112JX: RES ARRAY 4 RES 1.1K OHM 0804; Manufacturer: Panasonic Electronic Components; Circuit Type: Isolated; Database Table Name: Resistors -  Arrays and Networks; Number of Resistors: 4; Power Per Element: 62.5mW; Temperature Coefficient: ±200ppm/°C; Tolerance: ±5%; Applications: Automotive AEC-Q200; Component Kind: Standard; ComponentLink1Description: Datasheet; ComponentLink1URL: https://industrial.panasonic.com/cdbs/www-data/pdf/AOC0000/AOC0000C14.pdf; ComponentLink2Description: DigiKey Link; ComponentLink2URL: http://digikey.com/product-detail/en/panasonic-electronic-components/EXB-28V112JX/Y7112CT-ND/417449; Device Package: 0804, Convex, Long Side Terminals; Height - Seated (Max): 0.018" (0.45mm); LastUpdated: 1/21/2020 3:38:49 AM; Library Name: Celestial Altium Library - altium_library (3).DbLib; Lifecycle Status: Active; Minimum Order: 1; Mounting Type: Surface Mount; Number of Pins: 8; Operating Temperature: -55°C ~ 125°C; Package / Case: 0804, Convex, Long Side Terminals; Packaging: TapeAndReel; Part Number: EXB-28V112JX; Part Status: Active; PartId: 407; Price: 0.03; Resistance (Ohms): 1.1k; Series: EXB; Size / Dimension: 0.079" L x 0.039" W (2.00mm x 1.00mm); Supplier 1: DigiKey; Supplier Device Package: 0804; Supplier Part Number 1: Y7112CT-ND
R7-R9 = RNCS0603BKE10K0: RES 10K OHM 0.1% 1/16W 0603; Manufacturer: Stackpole Electronics Inc; Database Table Name: Resistors - Surface Mount - 0603; Power (Watts): 0.063W, 1/16W; Resistance: 10 kOhms; Temperature Coefficient: ±25ppm/°C; Component Kind: Standard; ComponentLink1Description: Datasheet; ComponentLink1URL: https://www.seielect.com/catalog/sei-rncs_rnch.pdf; ComponentLink2Description: DigiKey Link; ComponentLink2URL: http://digikey.com/product-detail/en/stackpole-electronics-inc/RNCS0603BKE10K0/RNCS0603BKE10K0CT-ND/1800113; Composition: Thin Film; Device Package: 0603 (1608 Metric); Features: Anti-Corrosive, Automotive AEC-Q200, Moisture Resistant; Height - Seated (Max): 0.022" (0.55mm); LastUpdated: 1/21/2020 12:25:01 AM; Library Name: Celestial Altium Library - altium_library (3).DbLib; Lifecycle Status: Active; Minimum Order: 1; Number of Terminations: 2; Operating Temperature: -55°C ~ 155°C; Package / Case: 0603 (1608 Metric); Packaging: TapeAndReel; Part Number: RNCS0603BKE10K0; Part Status: Active; PartId: 4838; Price: 0.08; Ratings: AEC-Q200; Series: RNCS; Size / Dimension: 0.061" L x 0.031" W (1.55mm x 0.80mm); Supplier 1: DigiKey; Supplier Device Package: 0603; Supplier Part Number 1: RNCS0603BKE10K0CT-ND; Tolerance: ±0.1%; Value: 1 kOhms
S1 = FSM1LP: SWITCH TACTILE SPST-NO 0.05A 24V; Manufacturer: TE Connectivity ALCOSWITCH Switches; Actuator Height off PCB, Vertical: 3.10mm; Actuator Orientation: Top Actuated; Actuator Type: Standard; Contact Rating @ Voltage: 0.05A @ 24VDC; Database Table Name: Switches - Tactile; Illumination: Non-Illuminated; Operating Force: 160gf; Outline: 6.00mm x 6.00mm; Switch Function: Off-Mom; Circuit: SPST-NO; Component Kind: Standard; ComponentLink1Description: Datasheet; ComponentLink1URL: https://www.te.com/commerce/DocumentDelivery/DDEController?Action=srchrtrv&DocNm=1-1437565-8&DocType=Customer+Drawing&DocLang=English; ComponentLink2Description: DigiKey Link; ComponentLink2URL: http://digikey.com/product-detail/en/te-connectivity-alcoswitch-switches/FSM1LP/450-1132-ND/525816; Features: Grounding Pin; LastUpdated: 2/4/2020 2:40:32 PM; Library Name: Celestial Altium Library - altium_library (3).DbLib; Lifecycle Status: Active; Minimum Order: 1; Mounting Type: Surface Mount; Operating Temperature: -35°C ~ 85°C; Packaging: Bulk; Part Number: FSM1LP; Part Status: Active; Price: 0.51; Series: FSM1LP; Supplier 1: DigiKey; Supplier Part Number 1: 450-1132-ND; Termination Style: Gull Wing
SW1 = 218-2LPST: SWITCH SLIDE DIP SPST 25MA 24V; Manufacturer: CTS Electrocomponents; Actuator Level: Flush, Recessed; Actuator Type: Slide (Standard); Database Table Name: Switches - DIP; Number of Positions: 2; Pitch: 0.050" (1.27mm), Half; Voltage Rating: 24VDC; Washable: Yes; Circuit: SPST; Component Kind: Standard; ComponentLink1Description: Datasheet; ComponentLink1URL: https://www.ctscorp.com/wp-content/uploads/218.pdf; ComponentLink2Description: DigiKey Link; ComponentLink2URL: http://digikey.com/product-detail/en/cts-electrocomponents/218-2LPST/CT2182LPST-ND/267319; Contact Finish: Gold; Contact Material: Beryllium Copper; Current Rating (Amps): 25mA; Features: Epoxy Sealed Terminals, Tape Seal; Height Above Board: 0.104" (2.65mm); LastUpdated: 1/4/2020 6:30:26 PM; Library Name: Celestial Altium Library - altium_library (3).DbLib; Lifecycle Status: Active; Minimum Order: 1; Mounting Type: Surface Mount; Operating Temperature: -55°C ~ 85°C; Packaging: Tube; Part Number: 218-2LPST; Part Status: Active; PartId: 3; Price: 1.04; Series: 218; Supplier 1: DigiKey; Supplier Part Number 1: CT2182LPST-ND; Termination Style: Gull Wing
U1 = ESP32-WROOM-32: Bluetooth, WiFi Transceiver Module 2.4GHz ~ 2.5GHz Surface Mount; MF: Espressif Systems; Component Kind: Standard; Digi-Key_Part_Number: 1904-1010-1-ND; Digi-Key_Purchase_URL: https://www.digikey.com/product-detail/en/espressif-systems/ESP32-WROOM-32/1904-1010-1-ND/8544305?utm_source=snapeda&utm_medium=aggregator&utm_campaign=symbol; Library Name: ESP32-WROOM-32.IntLib; MP: ESP32-WROOM-32; Package: Module Espressif Systems; SnapEDA_Link: https://www.snapeda.com/parts/ESP32-WROOM-32/Espressif%20Systems/view-part/2753322/?ref=snap
U2 = CP2104: USB Bridge, USB to UART USB 2.0 UART Interface 24-QFN _4x4_; MF: Silicon Labs; Availability: Unavailable; Component Kind: Standard; Library Name: CP2104.IntLib; MP: CP2104; Package: QFN-24 Silicon Labs; Price: None; SnapEDA_Link: https://www.snapeda.com/parts/CP2104/Silicon%20Labs/view-part/135877/?ref=snap
U3 = BME280: Humidity Temperature Sensor 0 ~ 100% RH IÂ²C, SPI Â±3% 1s Surface Mount; MF: Bosch; Availability: Unavailable; Component Kind: Standard; Library Name: BME280.IntLib; MP: BME280; Package: LGA-8 Bosch; Price: None; SnapEDA_Link: https://www.snapeda.com/parts/BME280/Bosch%20Sensortec/view-part/49769/?ref=snap
U4 = RFM95W-915S2: General ISM < 1GHz LoRaâ„¢ Transceiver Module 915MHz Surface Mount; MF: RF Solutions; Availability: Unavailable; Component Kind: Standard; Library Name: RFM95W-915S2.IntLib; MP: RFM95W-915S2; Package: SMD-16 RF Solutions; Price: None; SnapEDA_Link: https://www.snapeda.com/parts/RFM95W-915S2/RF%20Solutions/view-part/585488/?ref=snap
 = U.FL-R-SMT: U.FL _UMCC_ Connector Receptacle, Male Pin 50Ohm Surface Mount Solder; MF: Hirose Electric; Availability: Unavailable; Component Kind: Standard; Library Name: U.FL-R-SMT.IntLib; MP: U.FL-R-SMT; Package: None; Price: None; SnapEDA_Link: https://www.snapeda.com/parts/U.FL-R-SMT/Hirose%20Electric%20Co%20Ltd/view-part/470271/?ref=snap
